# Altium schematic sheet typewritercard.SchDoc
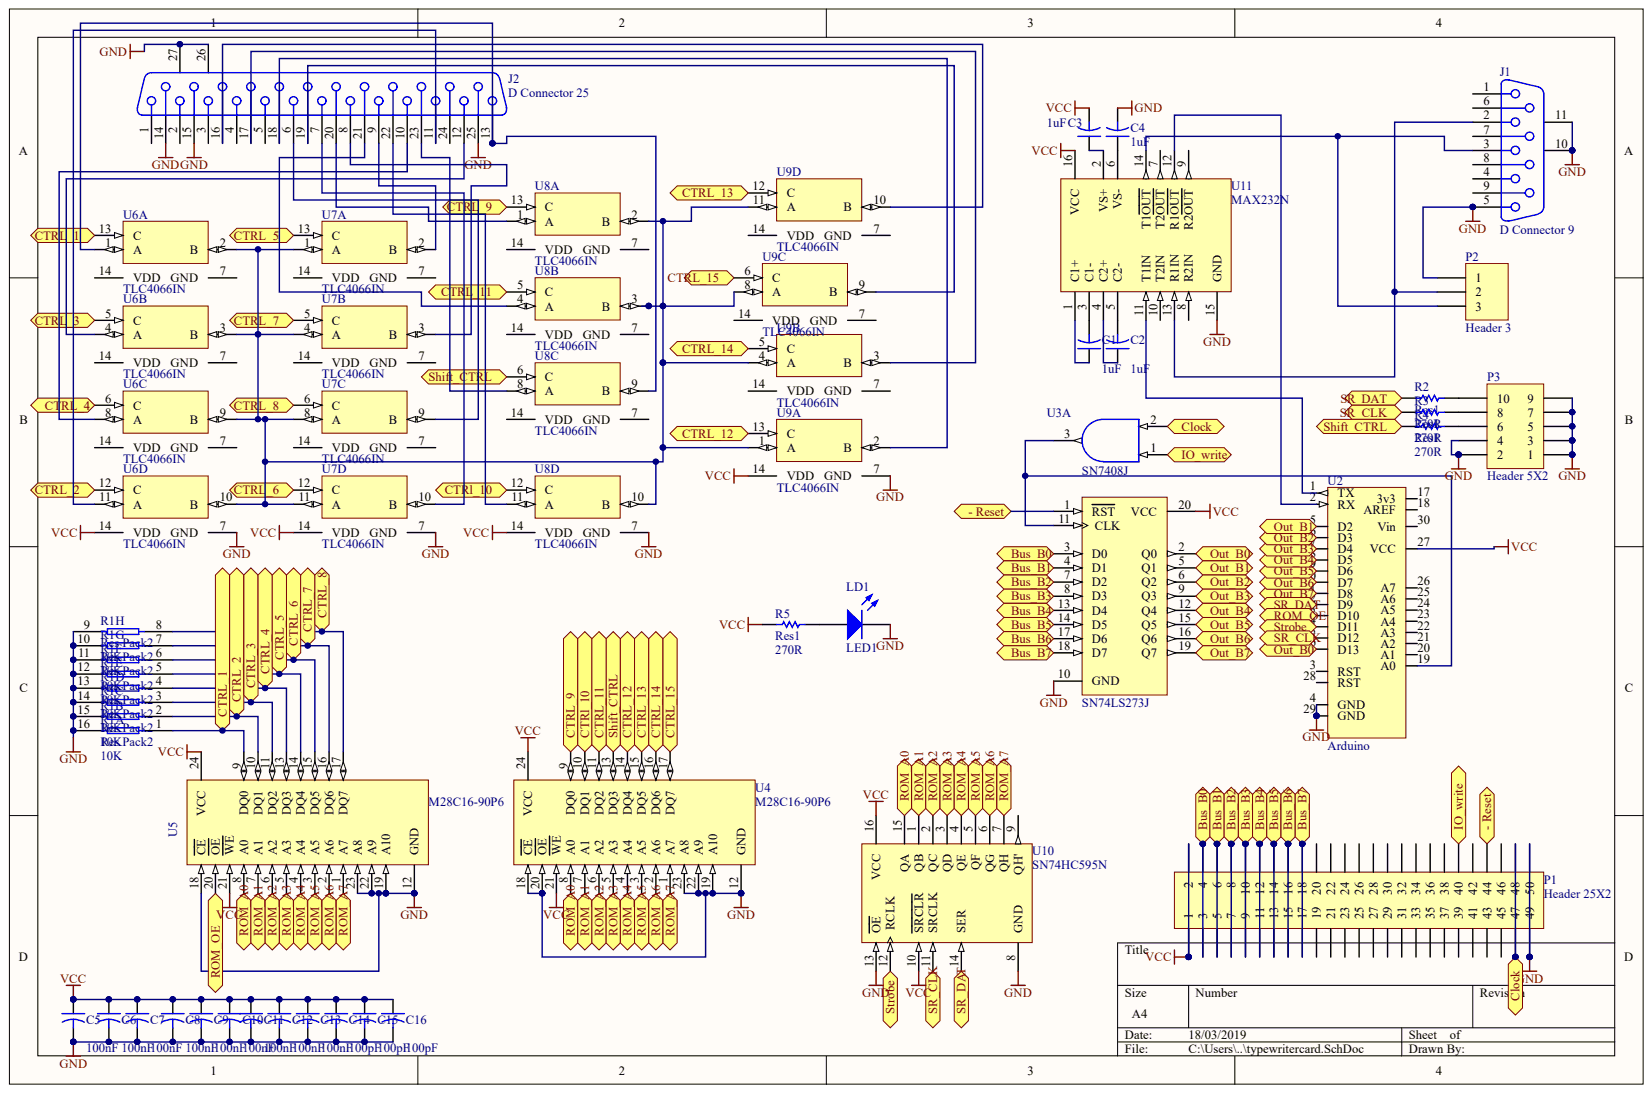
[diagram p0: typewritercard.SchDoc]

## Parts
C1  value 1uF  (hidden comment: Cap2)
C2  value 1uF  (hidden comment: Cap2)
C3  value 1uF  (hidden comment: Cap2)
C4  value 1uF  (hidden comment: Cap2)
C5  value 100nF  (hidden comment: Cap2)
C6  value 100nF  (hidden comment: Cap2)
C7  value 100nF  (hidden comment: Cap2)
C8  value 100nF  (hidden comment: Cap2)
C9  value 100nF  (hidden comment: Cap2)
C10  value 100nF  (hidden comment: Cap2)
C11  value 100nF  (hidden comment: Cap2)
C12  value 100nF  (hidden comment: Cap2)
C13  value 100nF  (hidden comment: Cap2)
C14  value 100pF  (hidden comment: Cap2)
C15  value 100pF  (hidden comment: Cap2)
C16  value 100pF  (hidden comment: Cap2)
J1  D Connector 9
J2  D Connector 25
LD1  LED1
P1  Header 25X2
P2  Header 3
P3  Header 5X2
R1  Res Pack2  value 10K  (parts A,B,C,D,E,F,G,H)
R2  Res1  value 270R
R3  Res1  value 270R
R4  Res1  value 270R
R5  Res1  value 270R
U1  SN74LS273J
U2  Arduino
U3  SN7408J  (part A)
U4  M28C16-90P6
U5  M28C16-90P6
U6  TLC4066IN  (parts A,B,C,D)
U7  TLC4066IN  (parts A,B,C,D)
U8  TLC4066IN  (parts A,B,C,D)
U9  TLC4066IN  (parts A,B,C,D)
U10  SN74HC595N
U11  MAX232N

## Ports
- RESET, BUS_B0, BUS_B1, BUS_B2, BUS_B3, BUS_B4, BUS_B5, BUS_B6, BUS_B7, CLOCK, CTRL_1, CTRL_2, CTRL_3, CTRL_4, CTRL_5, CTRL_6, CTRL_7, CTRL_8, CTRL_9, CTRL_10, CTRL_11, CTRL_12, CTRL_13, CTRL_14, CTRL_15, IO_WRITE, OUT_B0, OUT_B1, OUT_B2, OUT_B3, OUT_B4, OUT_B5, OUT_B6, OUT_B7, ROM_A0, ROM_A1, ROM_A2, ROM_A3, ROM_A4, ROM_A5, ROM_A6, ROM_A7, ROM_OE, SHIFT_CTRL, SR_CLK, SR_DAT, STROBE

## Nets
GND: C4.2 C5.2 C6.2 C7.2 C8.2 C9.2 C10.2 C11.2 C12.2 C13.2 C14.2 C15.2 C16.2 J1.5 J1.10 J1.11 J2.14 J2.15 J2.25 J2.26 J2.27 LD1.2 P1.49 P1.50 P2.1 P3.1 P3.2 P3.3 P3.4 P3.5 P3.7 P3.9 R1.9(R1G) R1.10(R1F) R1.11(R1E) R1.12(R1D) R1.13(R1C) R1.14(R1B) R1.15(R1A) R1.16 U1.10(GND) U2.4(GND) U2.29(GND) U3.7 U4.12(GND) U4.18(CE) U4.19(A10) U4.20(OE) U4.22(A9) U4.23(A8) U5.12(GND) U5.18(CE) U5.19(A10) U5.22(A9) U5.23(A8) U6.7 U7.7 U8.7 U9.7 U10.8(GND) U10.13(OE) U11.15(GND)
VCC: C3.2 C5.1 C6.1 C7.1 C8.1 C9.1 C10.1 C11.1 C12.1 C13.1 C14.1 C15.1 C16.1 P1.1 P1.2 R5.1 U1.20(VCC) U2.27(VCC) U3.14 U4.21(WE) U4.24(VCC) U5.21(WE) U5.24(VCC) U6.14 U7.14 U8.14 U9.14 U10.10(SRCLR) U10.16(VCC) U11.16(VCC)
CTRL_1: R1.1 U5.9(DQ0) U6.13(C) ; port CTRL_1
CTRL_2: R1.2 U5.10(DQ1) U6.12(C) ; port CTRL_2
CTRL_3: R1.3 U5.11(DQ2) U6.5(C) ; port CTRL_3
CTRL_4: R1.4 U5.13(DQ3) U6.6(C) ; port CTRL_4
CTRL_5: R1.5 U5.14(DQ4) U7.13(C) ; port CTRL_5
CTRL_6: R1.6 U5.15(DQ5) U7.12(C) ; port CTRL_6
CTRL_7: R1.7 U5.16(DQ6) U7.5(C) ; port CTRL_7
CTRL_8: R1.8 U5.17(DQ7) U7.6(C) ; port CTRL_8
CTRL_9: U4.9(DQ0) U8.13(C) ; port CTRL_9
CTRL_11: U4.11(DQ2) U8.5(C) ; port CTRL_11
CTRL_12: U4.14(DQ4) U9.13(C) ; port CTRL_12
CTRL_13: U4.15(DQ5) U9.12(C) ; port CTRL_13
CTRL_14: U4.16(DQ6) U9.5(C) ; port CTRL_14
CTRL_15: U4.17(DQ7) U9.6(C) ; port CTRL_15
ROM_A0: U4.8(A0) U5.8(A0) U10.15(QA) ; port ROM_A0
ROM_A1: U4.7(A1) U5.7(A1) U10.1(QB) ; port ROM_A1
ROM_A2: U4.6(A2) U5.6(A2) U10.2(QC) ; port ROM_A2
ROM_A3: U4.5(A3) U5.5(A3) U10.3(QD) ; port ROM_A3
ROM_A4: U4.4(A4) U5.4(A4) U10.4(QE) ; port ROM_A4
ROM_A5: U4.3(A5) U5.3(A5) U10.5(QF) ; port ROM_A5
ROM_A6: U4.2(A6) U5.2(A6) U10.6(QG) ; port ROM_A6
ROM_A7: U4.1(A7) U5.1(A7) U10.7(QH) ; port ROM_A7
ROM_OE: U2.13(D10) U5.20(OE) ; port ROM_OE
SR_CLK: R3.1 U2.15(D12) U10.11(SRCLK) ; port SR_CLK
SR_DAT: R2.1 U2.12(D9) U10.14(SER) ; port SR_DAT
NetC1_1: C1.1 U11.1(C1+)
NetC1_2: C1.2 U11.3(C1-)
NetC2_1: C2.1 U11.4(C2+)
NetC2_2: C2.2 U11.5(C2-)
NetC3_1: C3.1 U11.2(VS+)
NetC4_1: C4.1 U11.6(VS-)
NetJ1_2: J1.2 P2.2 U11.13(R1IN)
NetJ1_3: J1.3 P2.3 U11.14(T1OUT)
NetJ2_6: J2.6 U7.9(B)
NetJ2_7: J2.7 U7.10(B)
NetJ2_8: J2.8 U7.3(B)
NetJ2_9: J2.9 U7.2(B)
NetJ2_10: J2.10 U6.8(A)
NetJ2_11: J2.11 U6.11(A)
NetJ2_12: J2.12 U6.4(A)
NetJ2_13: J2.13 U6.1(A) U8.9(B)
NetJ2_16: J2.16 U9.10(B)
NetJ2_17: J2.17 U9.3(B)
NetJ2_18: J2.18 U9.2(B)
NetJ2_19: J2.19 U9.9(B)
NetJ2_20: J2.20 U8.1(A)
NetJ2_21: J2.21 U8.4(A)
NetJ2_22: J2.22 U8.11(A)
NetJ2_23: J2.23 U8.8(A)
NetLD1_1: LD1.1 R5.2
NetP1_3: P1.3 P1.4 U1.3(D0) ; port BUS_B0
NetP1_5: P1.5 P1.6 U1.4(D1) ; port BUS_B1
NetP1_7: P1.7 P1.8 U1.7(D2) ; port BUS_B2
NetP1_9: P1.9 P1.10 U1.8(D3) ; port BUS_B3
NetP1_11: P1.11 P1.12 U1.13(D4) ; port BUS_B4
NetP1_13: P1.13 P1.14 U1.14(D5) ; port BUS_B5
NetP1_15: P1.15 P1.16 U1.17(D6) ; port BUS_B6
NetP1_17: P1.17 P1.18 U1.18(D7) ; port BUS_B7
NetP1_40: P1.40 U3.1 ; port IO_WRITE
NetP1_44: P1.44 U1.1(RST) ; port - RESET
NetP1_47: P1.47 P1.48 U3.2 ; port CLOCK
NetP3_6: P3.6 R4.2
NetP3_8: P3.8 R3.2
NetP3_10: P3.10 R2.2
NetR4_1: R4.1 U4.13(DQ3) U8.6(C) ; port SHIFT_CTRL
NetU1_2: U1.2(Q0) U2.16(D13) ; port OUT_B0
NetU1_5: U1.5(Q1) U2.5(D2) ; port OUT_B1
NetU1_6: U1.6(Q2) U2.6(D3) ; port OUT_B2
NetU1_9: U1.9(Q3) U2.7(D4) ; port OUT_B3
NetU1_11: U1.11(CLK) U2.19(A0) U3.3
NetU1_12: U1.12(Q4) U2.8(D5) ; port OUT_B4
NetU1_15: U1.15(Q5) U2.9(D6) ; port OUT_B5
NetU1_16: U1.16(Q6) U2.10(D7) ; port OUT_B6
NetU1_19: U1.19(Q7) U2.11(D8) ; port OUT_B7
NetU2_1: U2.1(TX) U11.11(T1IN)
NetU2_2: U2.2(RX) U11.12(R1OUT)
NetU2_14: U2.14(D11) U10.12(RCLK) ; port STROBE
NetU4_10: U4.10(DQ1) U8.12(C) ; port CTRL_10
NetU6_2: U6.2(B) U6.3(B) U6.9(B) U6.10(B) U7.1(A) U7.4(A) U7.8(A) U7.11(A) U8.2(B) U8.3(B) U8.10(B) U9.1(A) U9.4(A) U9.8(A) U9.11(A)

Not connected: J1 1 4 6 7 8 9; J2 1 2 3 4 5 24; P1 19 20 21 22 23 24 25 26 27 28 29 30 31 32 33 34 35 36 37 38 39 41 42 43 45 46; U2 3(RST) 17(3v3) 18(AREF) 20(A1) 21(A2) 22(A3) 23(A4) 24(A5) 25(A6) 26(A7) 28(RST) 30(Vin); U10 9(QH'); U11 7(T2OUT) 8(R2IN) 9(R2OUT) 10(T2IN)

## Library data of the parts
J1 = (no symbol name): PackageDocument: O; Positions: 9; Gender: Receptacle; Published: 15-Jun-2001; LatestRevisionDate: 17-Jul-2002; LatestRevisionNote: Re-released for DXP Platform.; DatasheetDocument: 1-Sep-1999; PackageReference: 788750; Orientation: Right Angle; Publisher: Altium Limited
J2 = (no symbol name): PackageDocument: U; Positions: 25; Gender: Receptacle; Published: 15-Jun-2001; LatestRevisionDate: 17-Jul-2002; LatestRevisionNote: Re-released for DXP Platform.; DatasheetDocument: 19-Mar-1999; PackageReference: 787202; Orientation: Right Angle; Publisher: Altium Limited
R1 = (no symbol name): Sim Note: Enter the Resistance value into parameter field.; Published: 24-Mar-1999; LatestRevisionDate: 17-Jul-2002; LatestRevisionNote: Re-released for DXP Platform.; PackageReference: DIP-16; Code_JEDEC: MO-001-AC; Publisher: Altium Limited
R2 = (no symbol name): Value: 270R; Published: 8-Jun-2000; LatestRevisionDate: 17-Jul-2002; LatestRevisionNote: Re-released for DXP Platform.; PackageReference: AXIAL-0.3; PackageDescription: Axial Device, Thru-Hole; 2 Leads; 0.3 in Pin Spacing; Publisher: Altium Limited
U1 = (no symbol name): ComponentAvailability: Obsolete; Published: 8-Jun-2000; LatestRevisionDate: 04-Mar-2005; LatestRevisionNote: Stylized 3D Model Added.; DatasheetVersion: Mar-1988; PackageVersion: Jun-1999; PackageReference: J020; PackageDescription: DIP; 20 Leads; Row Spacing 7.62 mm; Pitch 2.54 mm; ComponentLink1Description: Manufacturer Link; ComponentLink1URL: http://www.ti.com/; ComponentLink2Description: Datasheet; ComponentLink2URL: http://www-s.ti.com/sc/ds/sn74273.pdf; ComponentLink3Description: Discontinuation Notice; ComponentLink3URL: http://focus.ti.com/docs/prod/folders/print/sn74ls273.html; Publisher: Altium Limited
U11 = (no symbol name): Note: Receivers have typical hysteresis of 0.5V; Published: 15-May-2002; LatestRevisionDate: 04-Mar-2005; LatestRevisionNote: Stylized 3D Model Added.; DatasheetVersion: Jun-1989; PackageVersion: Oct-1995; PackageReference: N016; PackageDescription: DIP; 16 Leads; Row Spacing 7.62 mm; Pitch 2.54 mm; Code_JEDEC: MS-001-BB; ComponentLink1Description: Manufacturer Link; ComponentLink1URL: http://www.ti.com/; ComponentLink2Description: Datasheet; ComponentLink2URL: http://www-s.ti.com/sc/ds/max232.pdf; Publisher: Altium Limited
C1-C16 LD1 P1-P3 R3-R5 U2-U10 = (no symbol name)
  C1-C16: Published: 23-Sep-2002; PackageReference: CAPR5-4X5; PackageDescription: Capacitor; 2 Leads
  LD1: Published: 8-Jun-2000; LatestRevisionDate: 08-Jul-2005; LatestRevisionNote: Mech Layer 1 removed.; PackageReference: LED-1; PackageDescription: LED; 2 Leads
  P1-P3: LatestRevisionDate: 17-Jul-2002; LatestRevisionNote: Re-released for DXP Platform.
  R3-R5: Published: 8-Jun-2000; LatestRevisionDate: 17-Jul-2002; LatestRevisionNote: Re-released for DXP Platform.; PackageReference: AXIAL-0.3; PackageDescription: Axial Device, Thru-Hole; 2 Leads; 0.3 in Pin Spacing
  U3: Published: 8-Jun-2000; LatestRevisionDate: 04-Mar-2005; LatestRevisionNote: Stylized 3D Model Added.; DatasheetVersion: Mar-1988; PackageVersion: Jun-1999; PackageReference: J014; PackageDescription: DIP; 14 Leads; Row Spacing 7.62 mm; Pitch 2.54 mm; ComponentLink1Description: Manufacturer Link; ComponentLink1URL: http://www.ti.com/; ComponentLink2Description: Datasheet; ComponentLink2URL: http://www-s.ti.com/sc/ds/sn54ls08.pdf; ComponentLink3Description: Packaging Specification; ComponentLink3URL: http://focus.ti.com/lit/ml/mcer002d/mcer002d.pdf
  U4 U5: Published: 24-Aug-2001; LatestRevisionDate: 06-Jun-2005; LatestRevisionNote: Stylized 3D Model Added.; DatasheetVersion: Nov-1997; PackageReference: PDIP24; PackageDescription: DIP; 24 Leads; Row Spacing 15.24 mm; Pitch 2.54 mm; ComponentLink1Description: Manufacturer Link; ComponentLink1URL: http://www.st.com/
  U6-U9: Published: 8-Jun-2000; LatestRevisionDate: 04-Mar-2005; LatestRevisionNote: Stylized 3D Model Added.; DatasheetVersion: Jan-1986; PackageVersion: Oct-1995; PackageReference: N014; PackageDescription: DIP; 14 Leads; Row Spacing 7.62 mm; Pitch 2.54 mm; ComponentLink1Description: Manufacturer Link; ComponentLink1URL: http://www.ti.com/
  U10: Published: 8-Jun-2000; LatestRevisionDate: 04-Mar-2005; LatestRevisionNote: Stylized 3D Model Added.; DatasheetVersion: May-1997; PackageVersion: Oct-1995; PackageReference: N016; PackageDescription: DIP; 16 Leads; Row Spacing 7.62 mm; Pitch 2.54 mm; Code_JEDEC: MS-001-BB; ComponentLink1Description: Manufacturer Link; ComponentLink1URL: http://www.ti.com/; ComponentLink2Description: Datasheet; ComponentLink2URL: http://www-s.ti.com/sc/ds/sn74hc595.pdf
  C1-C16 LD1 P1-P3 R3-R5 U3-U10: Publisher: Altium Limited
